# Altium schematic sheet thco2_sch.SchDoc
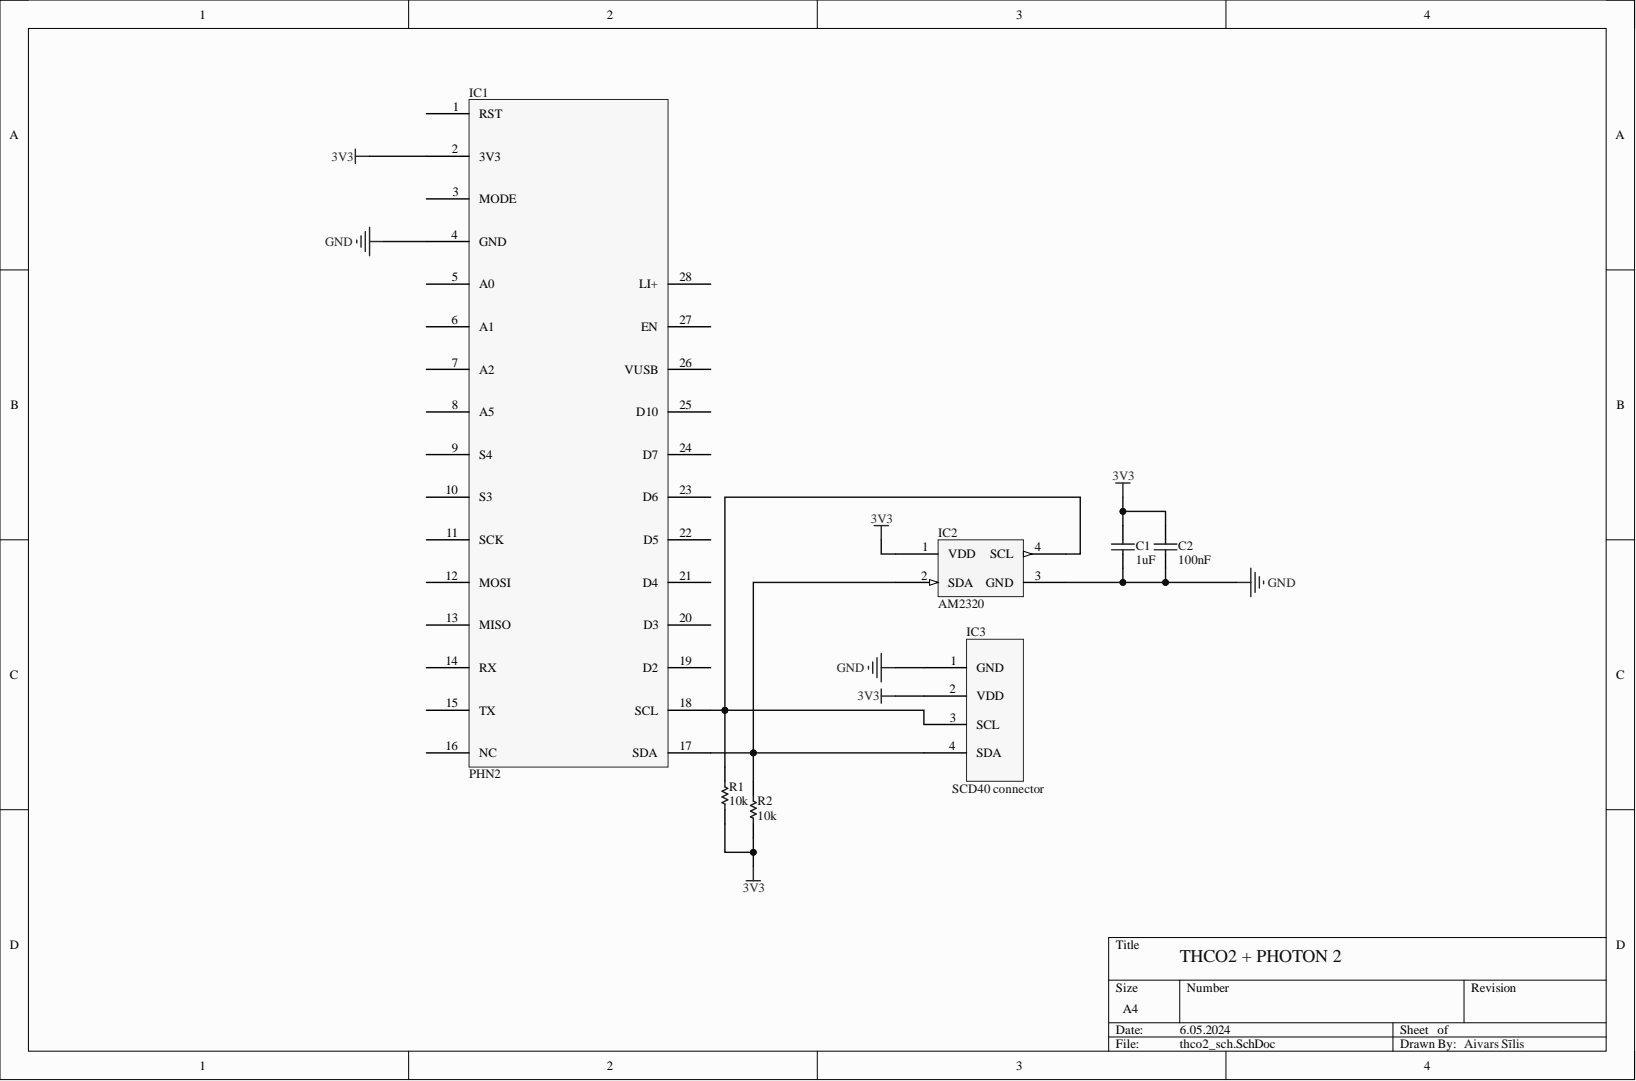
[diagram p0: thco2_sch.SchDoc]

## Parts
C1  1uF  [PCB-0002-00743-21]
C2  100nF  [PCB-0002-00743-21]
IC1  PHN2  [PHN2-Footprint-1]
IC2  AM2320  [PCB-nle7tp2tduiwjt1k6pug-1]
IC3  SCD40 connector  [SCD40-Footprint-1]
R1  10k  [RESC2013X60X35NL10T20]
R2  10k  [RESC2013X60X35NL10T20]

## Nets
3V3: C1.1 C2.1 IC1.2(3V3) IC2.1(VDD) IC3.2(VDD) R1.1 R2.1
GND: C1.2 C2.2 IC1.4(GND) IC2.3(GND) IC3.1(GND)
NetIC1_17: IC1.17(SDA) IC2.2(SDA) IC3.4(SDA) R2.2
NetIC1_18: IC1.18(SCL) IC2.4(SCL) IC3.3(SCL) R1.2

Not connected: IC1 1(RST) 3(MODE) 5(A0) 6(A1) 7(A2) 8(A5) 9(S4) 10(S3) 11(SCK) 12(MOSI) 13(MISO) 14(RX) 15(TX) 16(NC) 19(D2) 20(D3) 21(D4) 22(D5) 23(D6) 24(D7) 25(D10) 26(VUSB) 27(EN) 28(LI+)

## Library data of the parts
C1 C2 = CMP-126079-12: 0805 Cap Ceramic; Manufacturer: AVX; Manufacturer Part Number: 08051C103KAT2A; Capacitance: 1e-08 F; Lead-Free Status: Lead Free; Temperature Coefficient: X7R; Voltage Rating (DC): 100.0 V; Case: 0805; Component Kind: Standard; Library Name: My Personal Space; OctopartID: 6e45a58aebfbb182; Operating Temperature: -55°C ~ 125°C; REACH SVHC Compliance: No SVHC; RoHS: Compliant
IC1 = CMP-mzidzupoplw6vx27i7jt-2: Particle Photon 2; ReplaceComponentId: AWCAXZWI; Component Kind: Standard; CustomComponentID: mzidzupoplw6vx27i7jt; Library Name: My Personal Space; PartId: 1
IC2 = CMP-767eba14c447a9b9-8: 20" Diameter - 3 Speed - Quiet, Carrying Handle - 22.5" Height x 4.8" Width - Gray,...; Manufacturer Part Number: 3721; Component Kind: Standard; Library Name: My Personal Space; Octopart URL: https://octopart.com/3721-adafruit+industries-90216287; OctopartID: 767eba14c447a9b9; RoHS: Compliant
IC3 = CMP-r3dvg3noporsmc4y26za-1: 2.54mm x 4pins connector; Component Kind: Standard; CustomComponentID: r3dvg3noporsmc4y26za; Library Name: My Personal Space
R1 R2 = CMP-1854012-5: 4.99 kOhms ±1% 0.5W, 1/2W Chip Resistor 0805 (2012 Metric) Automotive AEC-Q200, Pulse Withstanding Thick Film; Manufacturer Part Number: CRCW08054K99FKEAHP; Case/Package (SI): 2012; Lead-Free Status: Lead Free; Power Rating: 0.125 W; Resistance: 4.99k; Resistance Tolerance: ±5%; Voltage Rating (DC): 150.0 V; Case/Package: 0805; Component Kind: Standard; Library Name: My Personal Space; Mounting Style: Surface Mount; Number of Pins: 2; Octopart Url: https://octopart.com/rc0805jr-072r2l-yageo-1223392; OctopartID: 7e7db765f1fba1ba; Packaging: Cut Tape (CT); RoHS: Compliant; Size-Height: 0.0005 m; Size-Length: 0.002 m; Size-Width: 0.00125 m
